# Altium schematic sheet ECG_Regulator.SchDoc(ECG_Regulator)
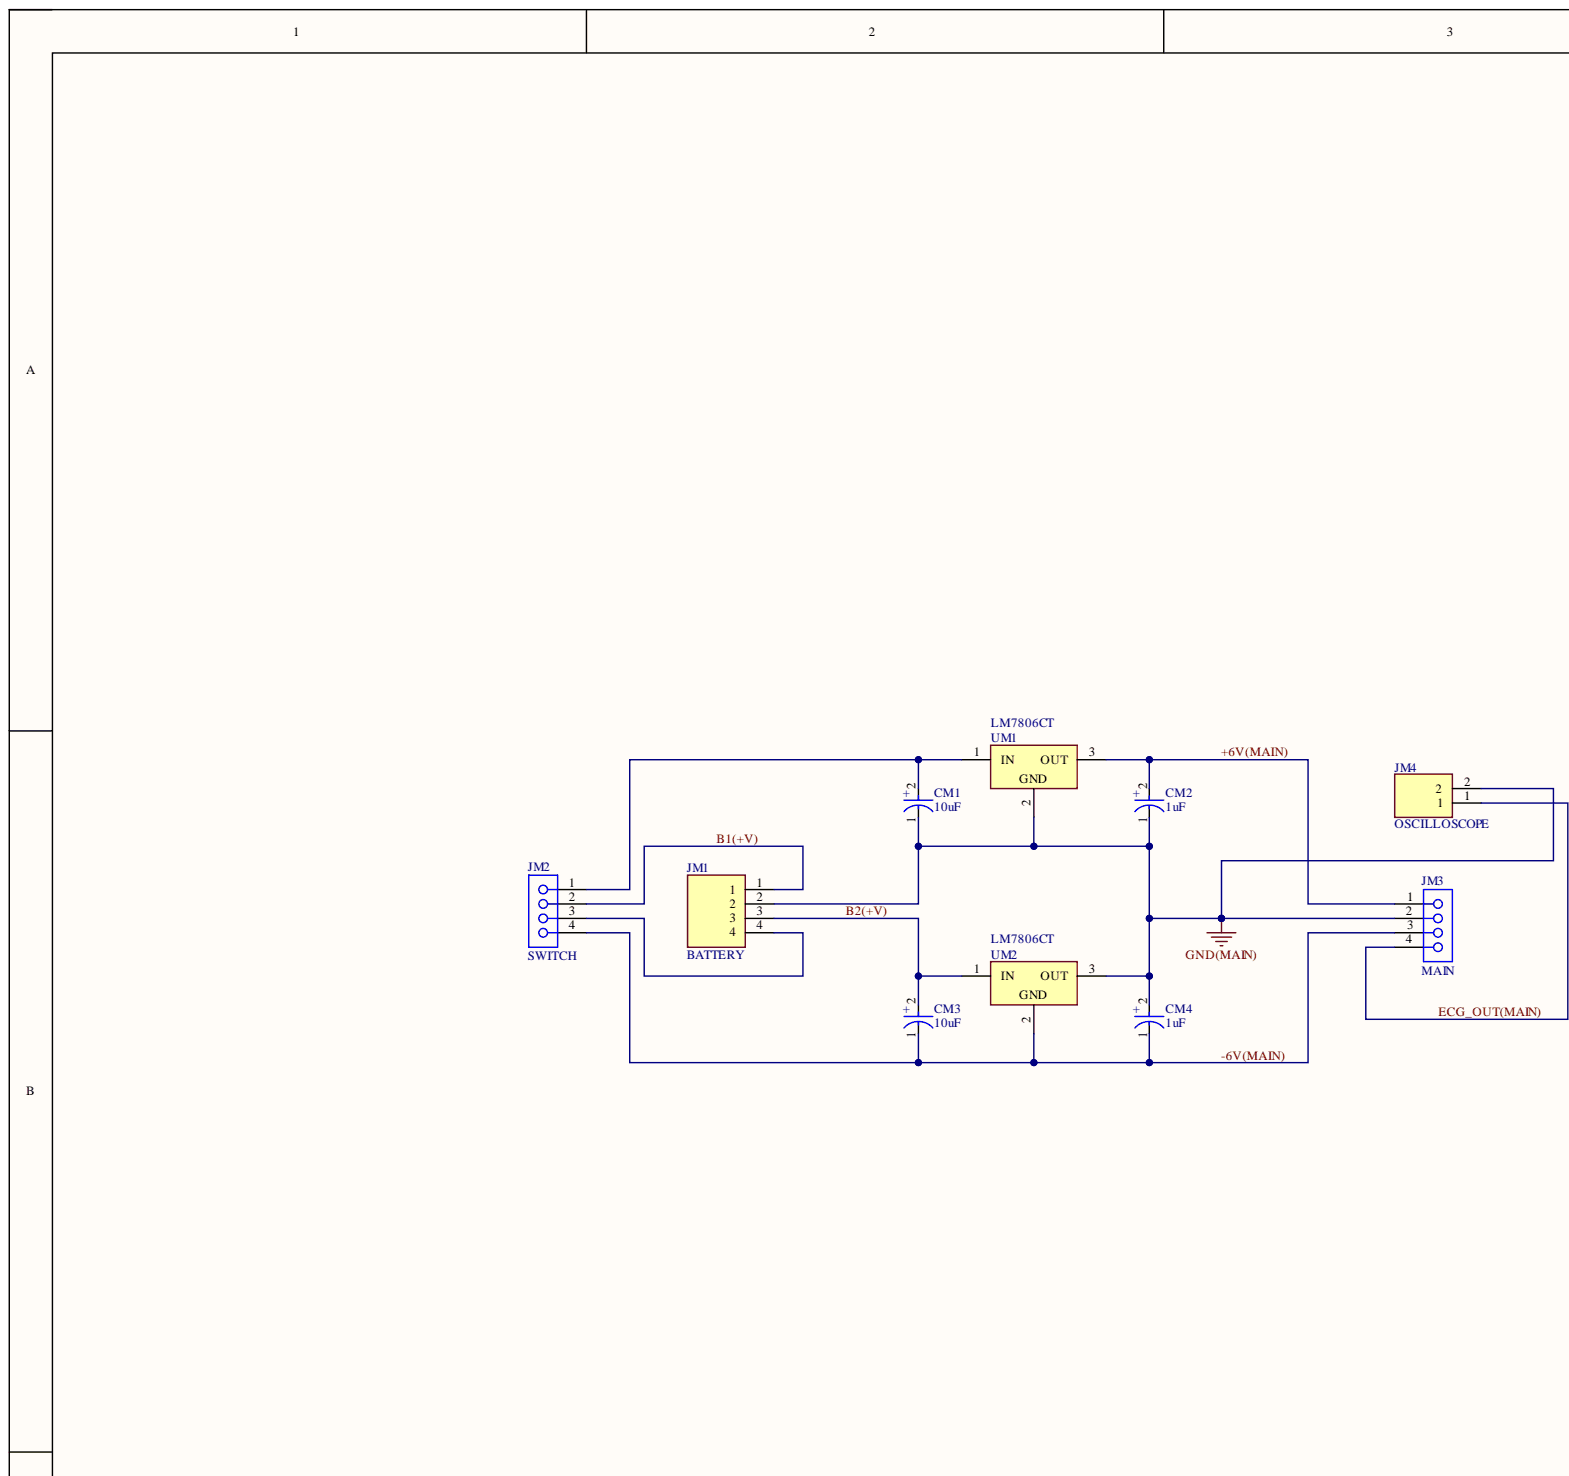
[diagram p0: ECG_Regulator.SchDoc, top left]
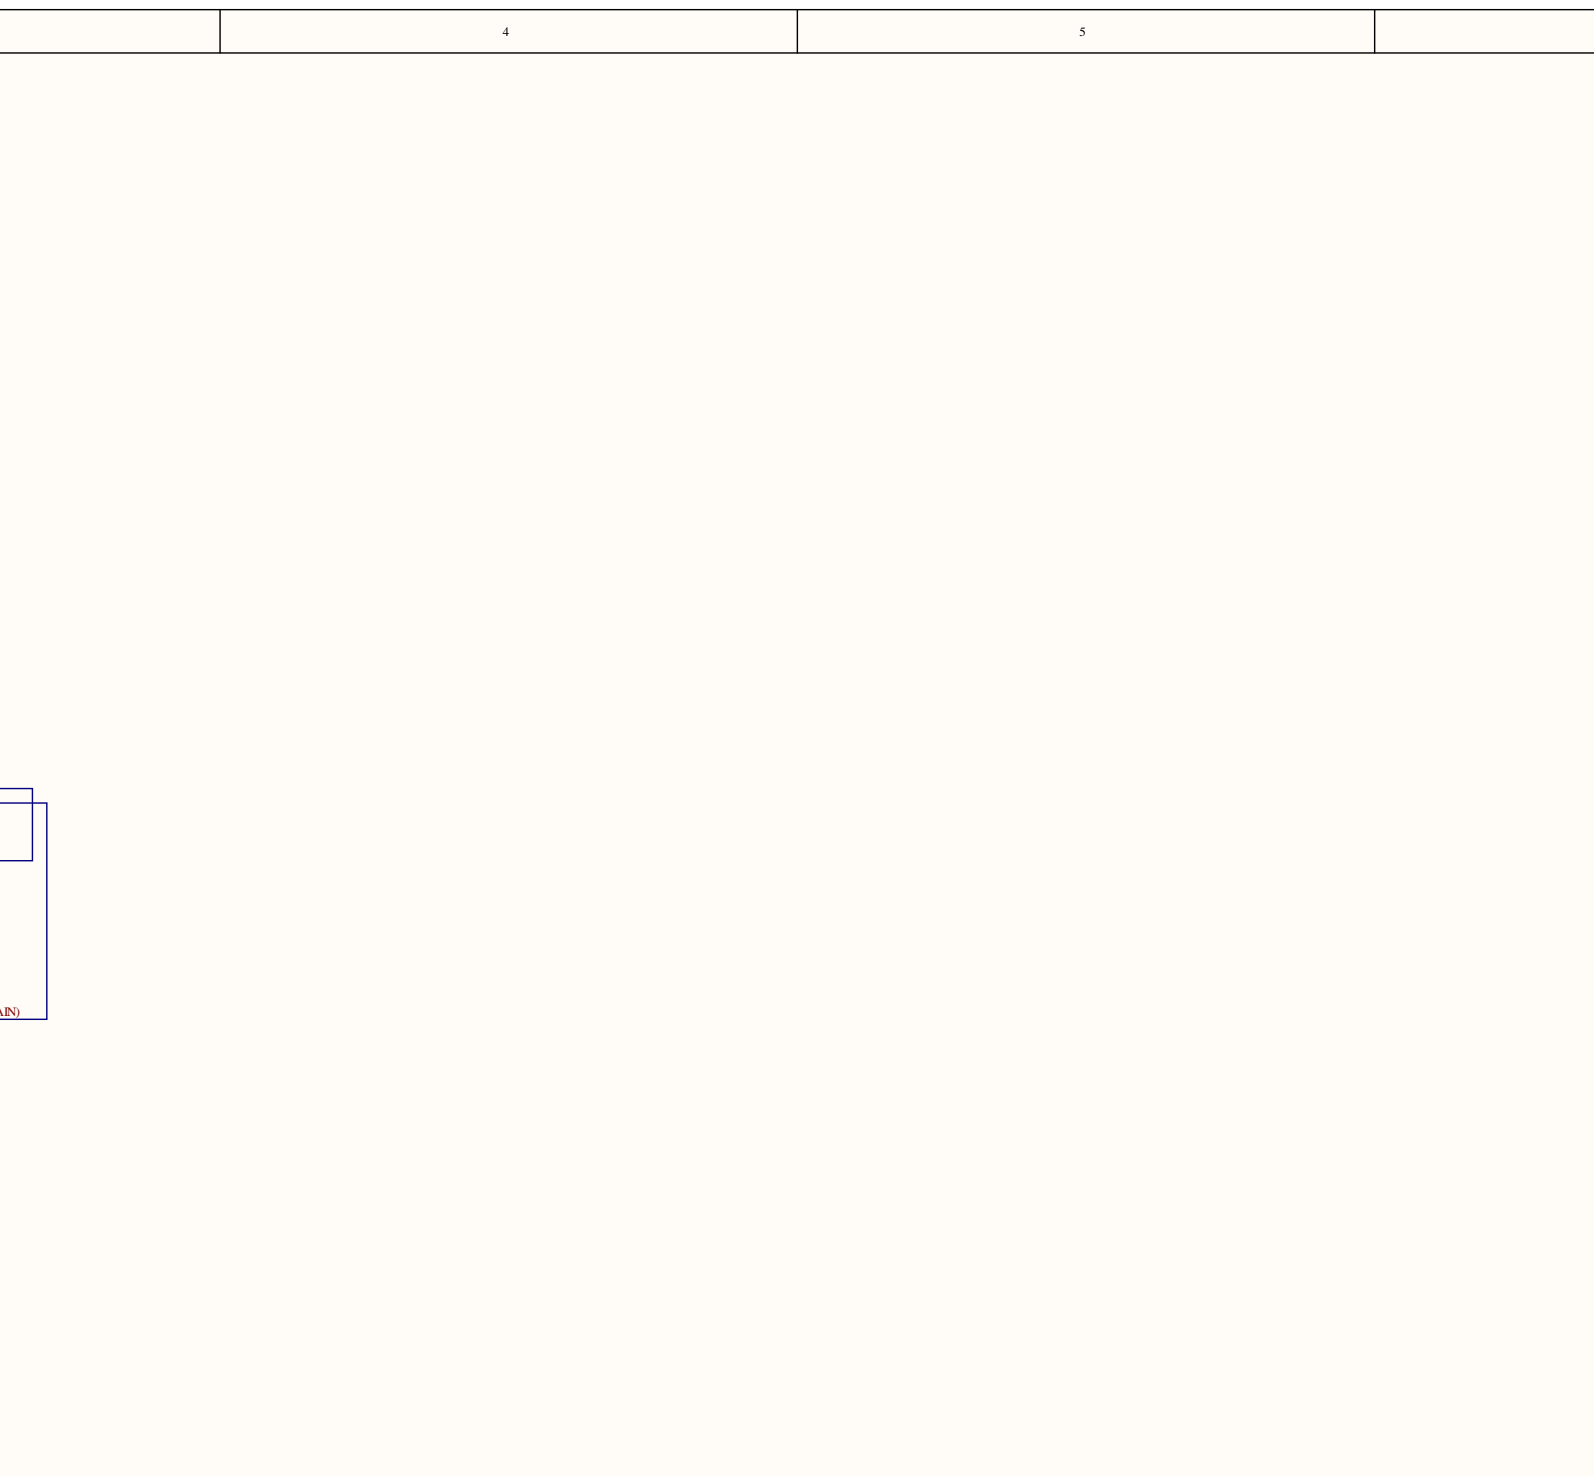
[diagram p1: ECG_Regulator.SchDoc, top centre]
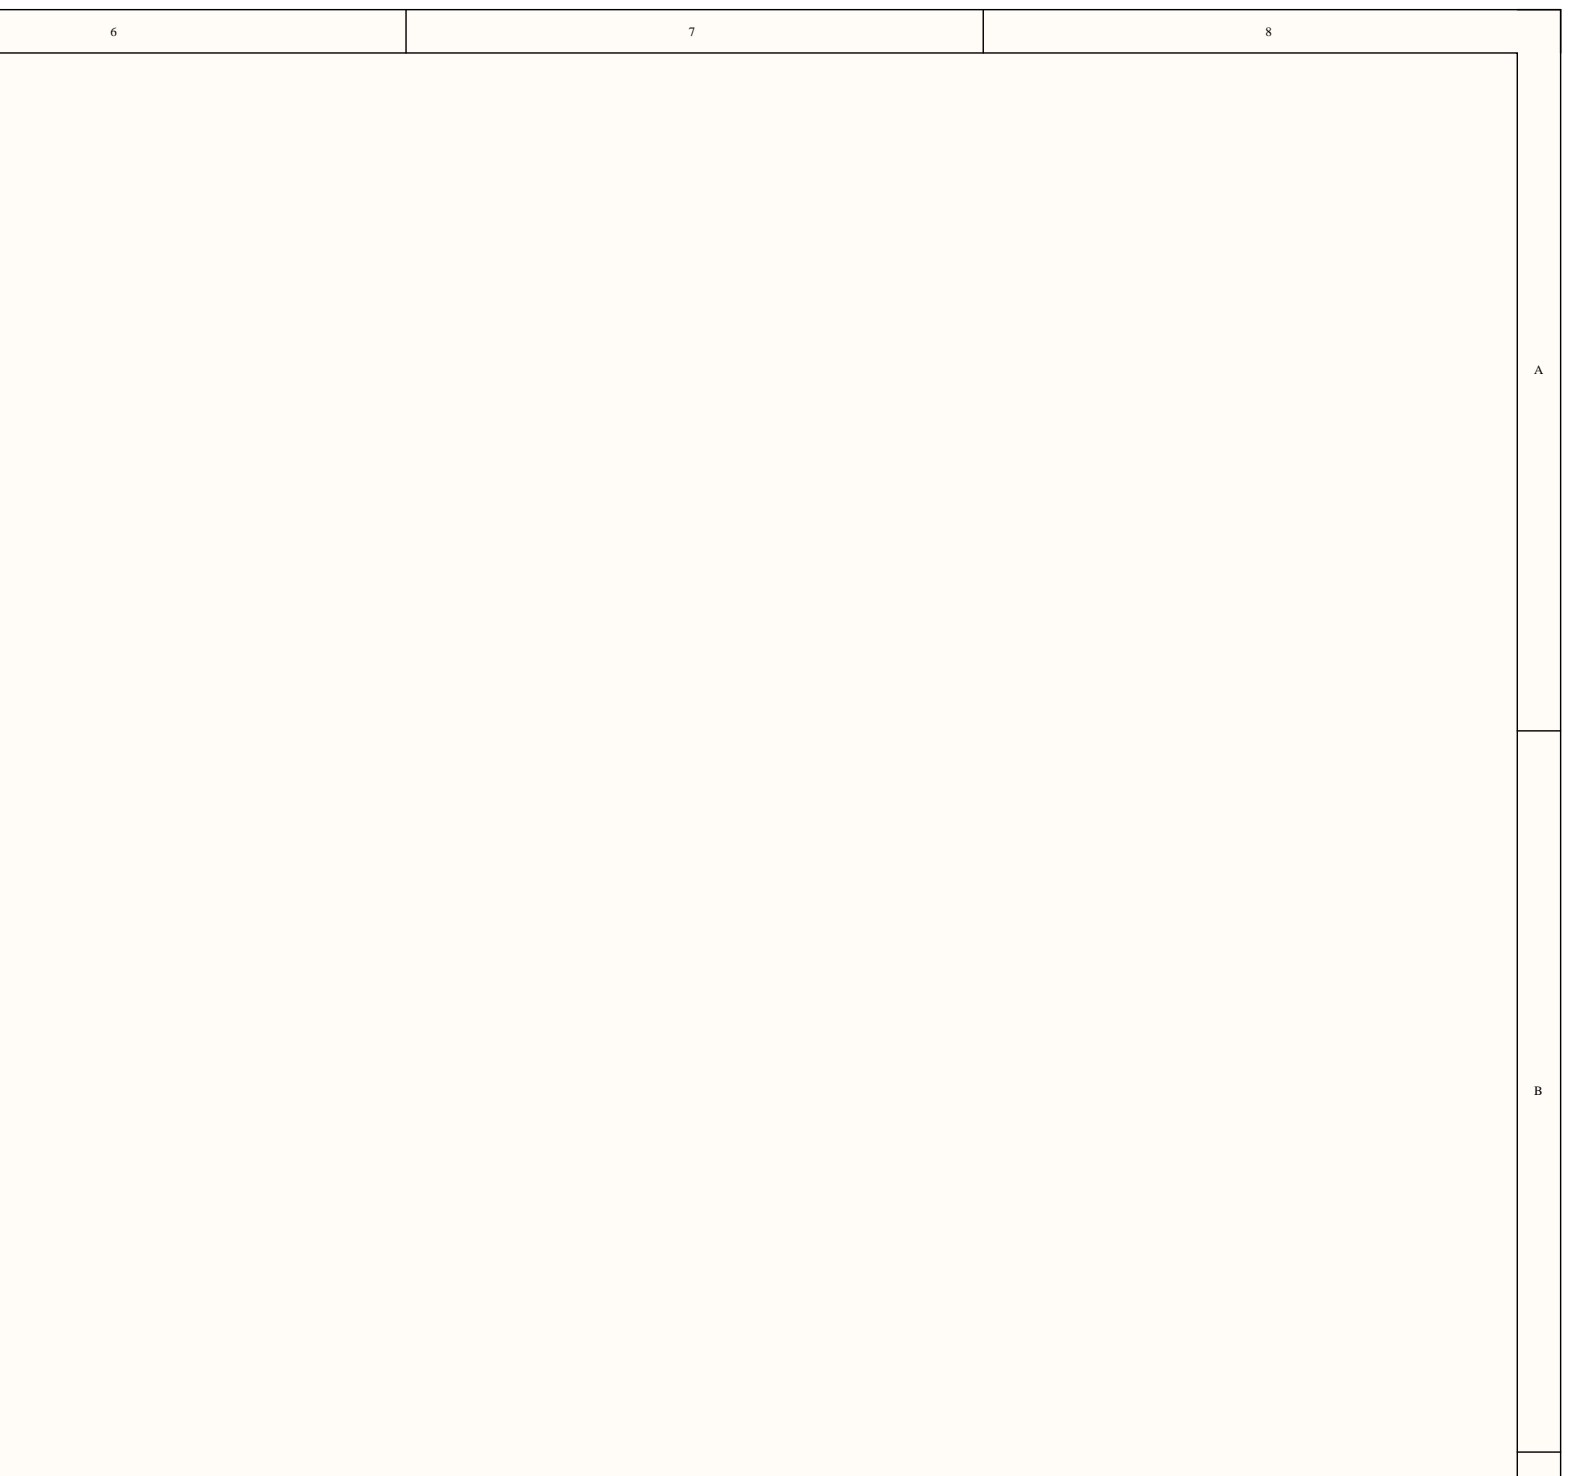
[diagram p2: ECG_Regulator.SchDoc, top right]
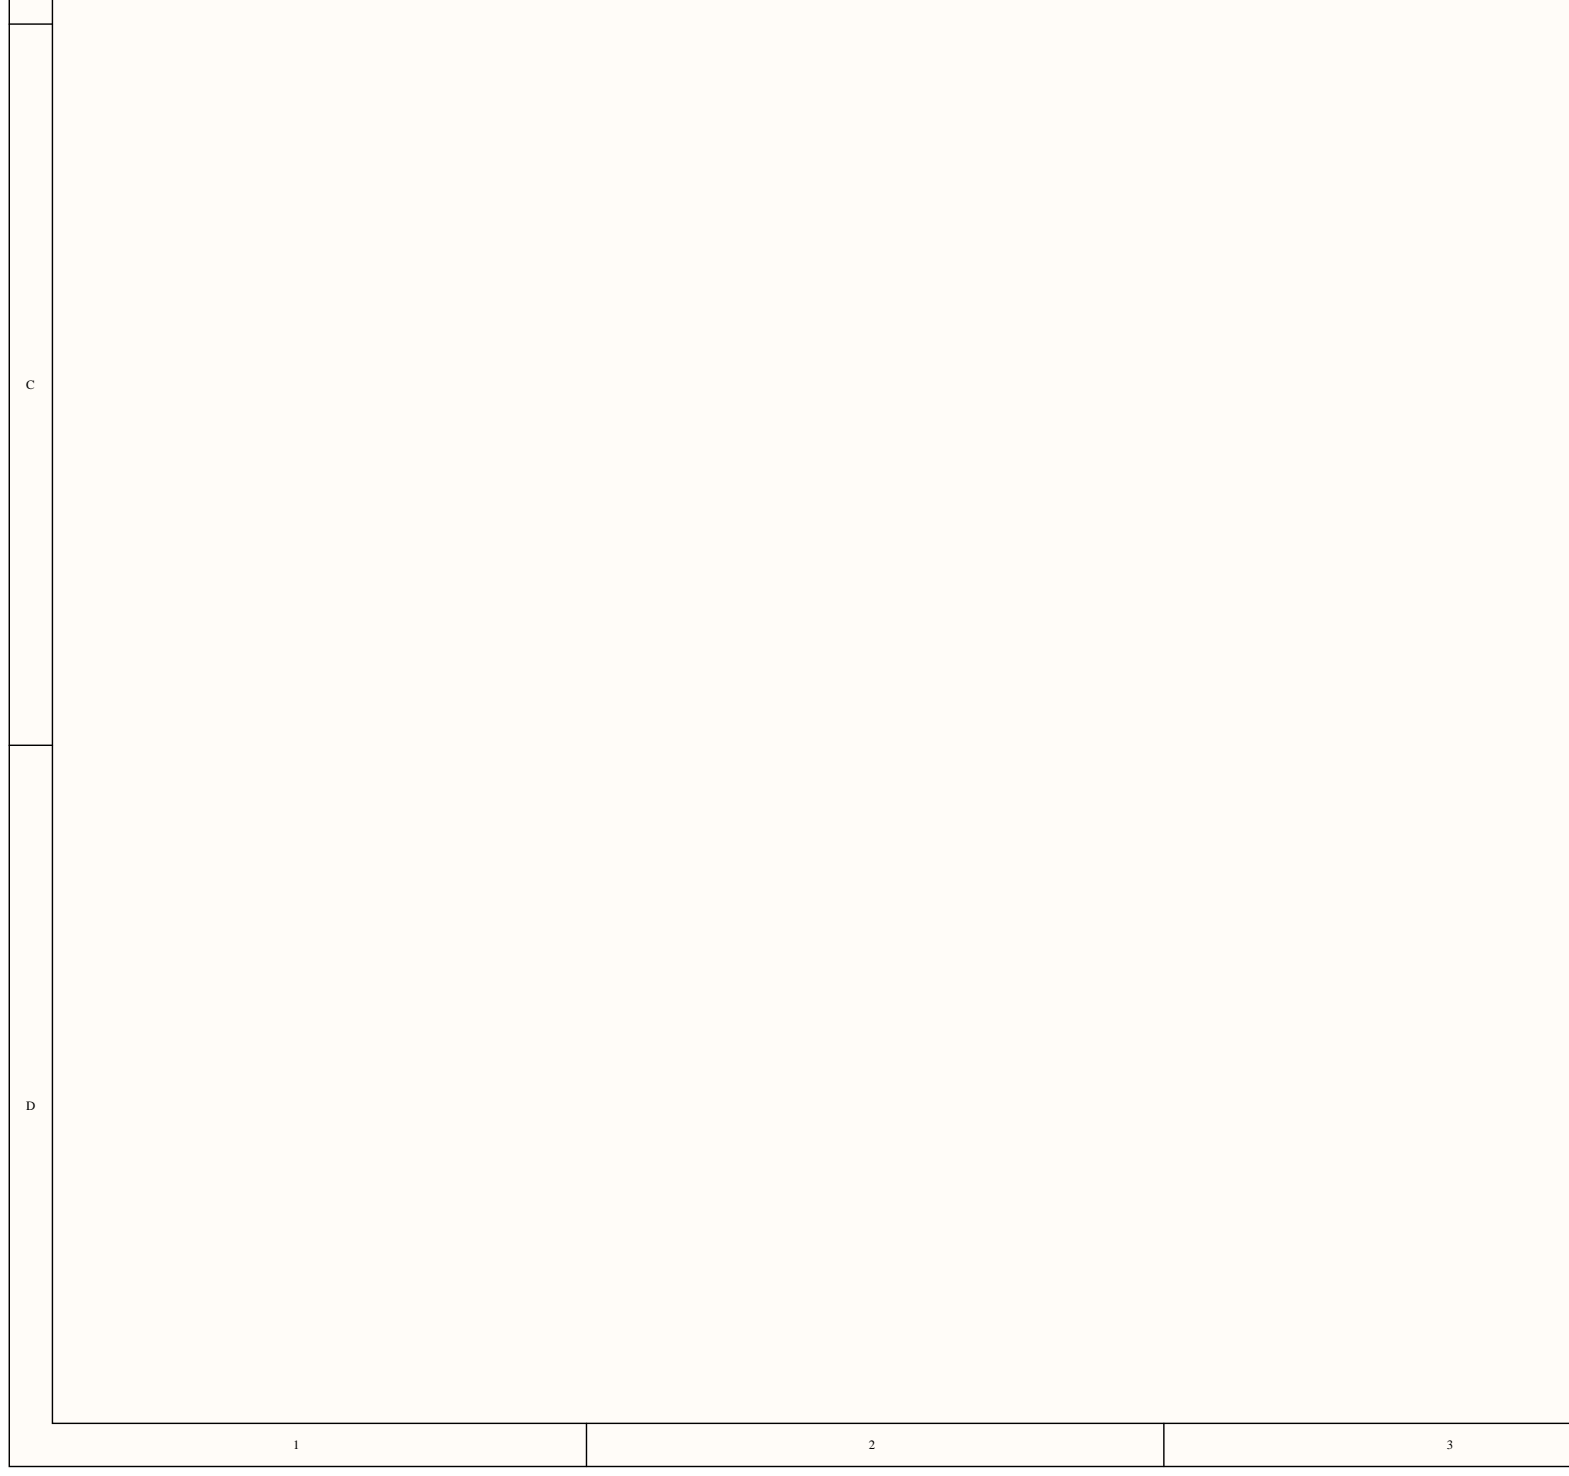
[diagram p3: ECG_Regulator.SchDoc, bottom left]
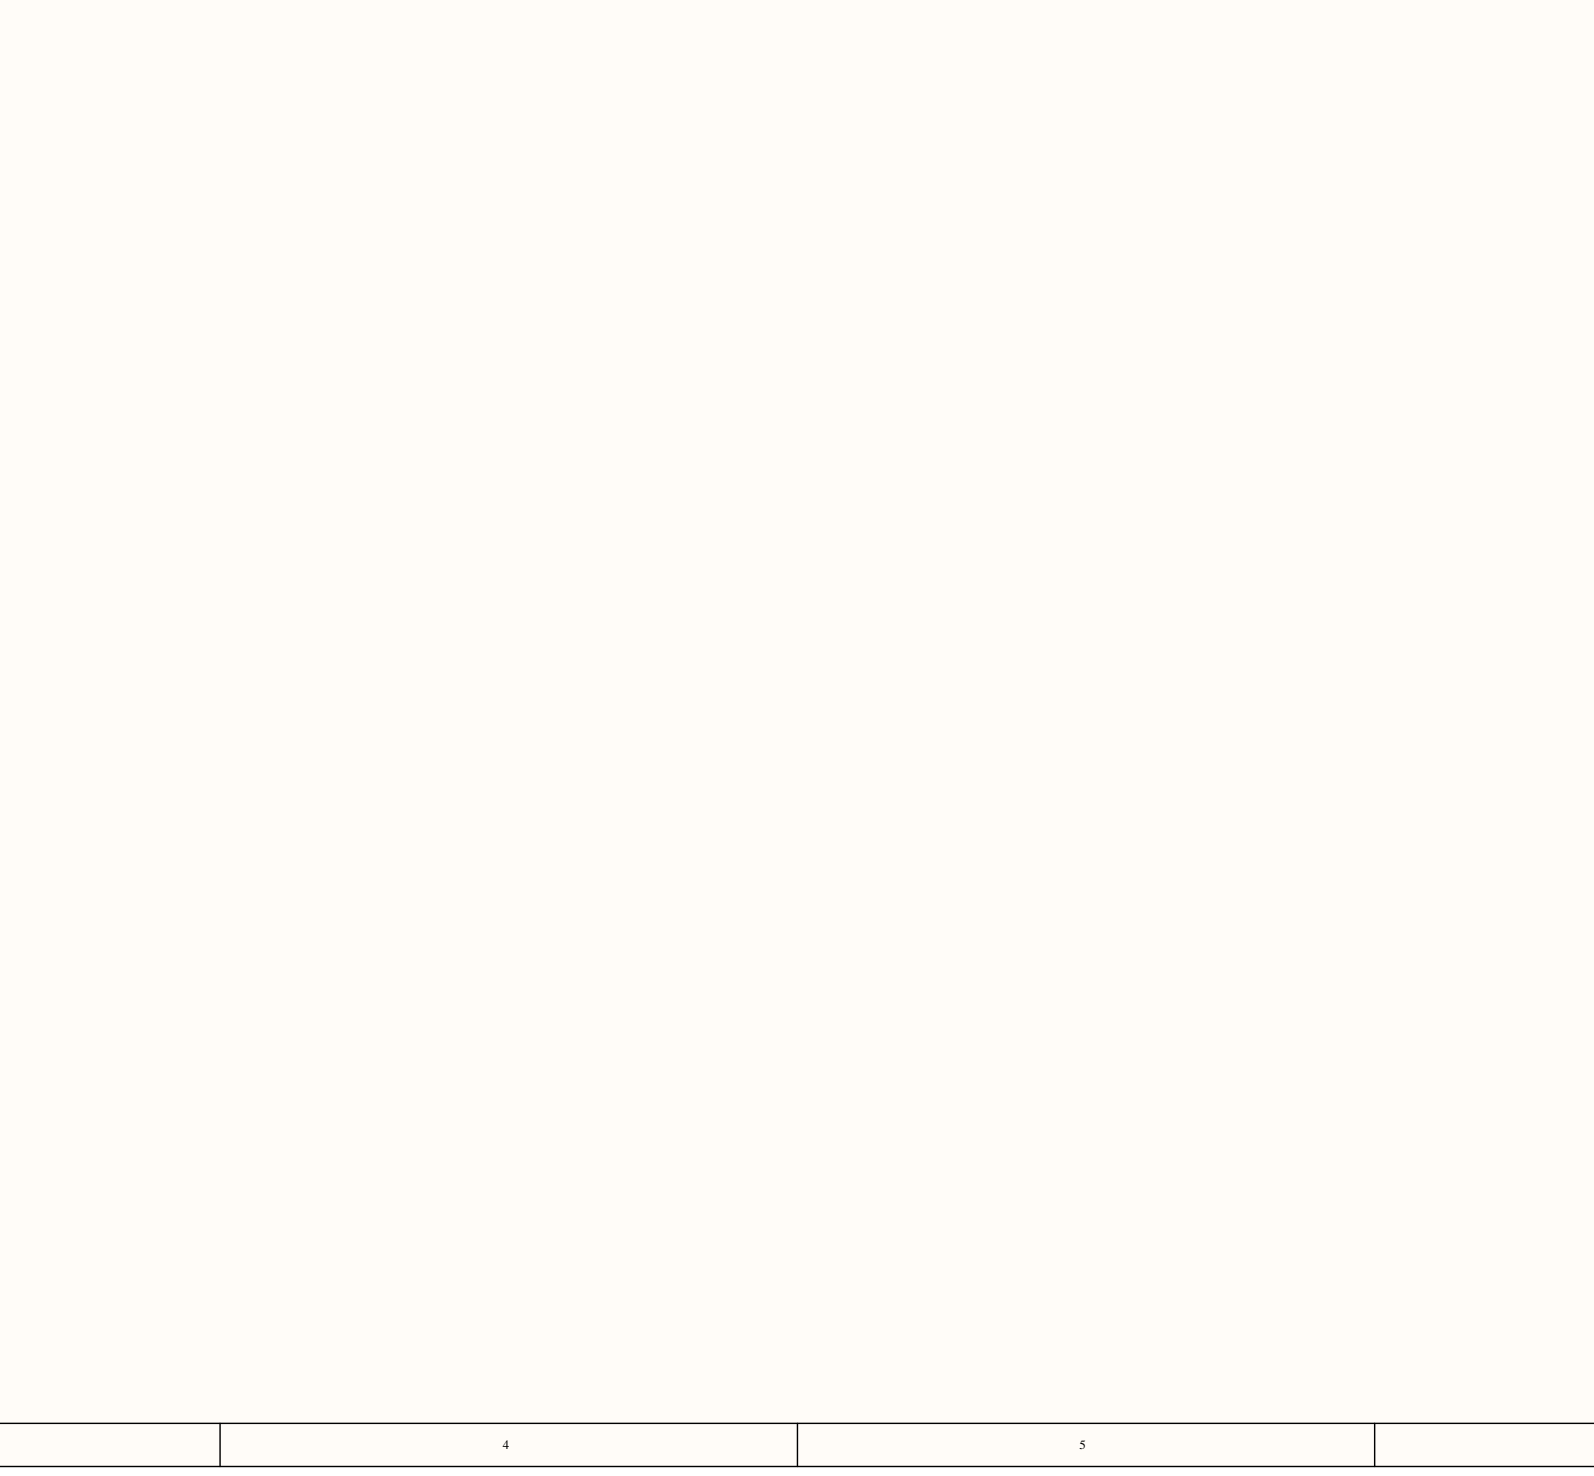
[diagram p4: ECG_Regulator.SchDoc, bottom centre]
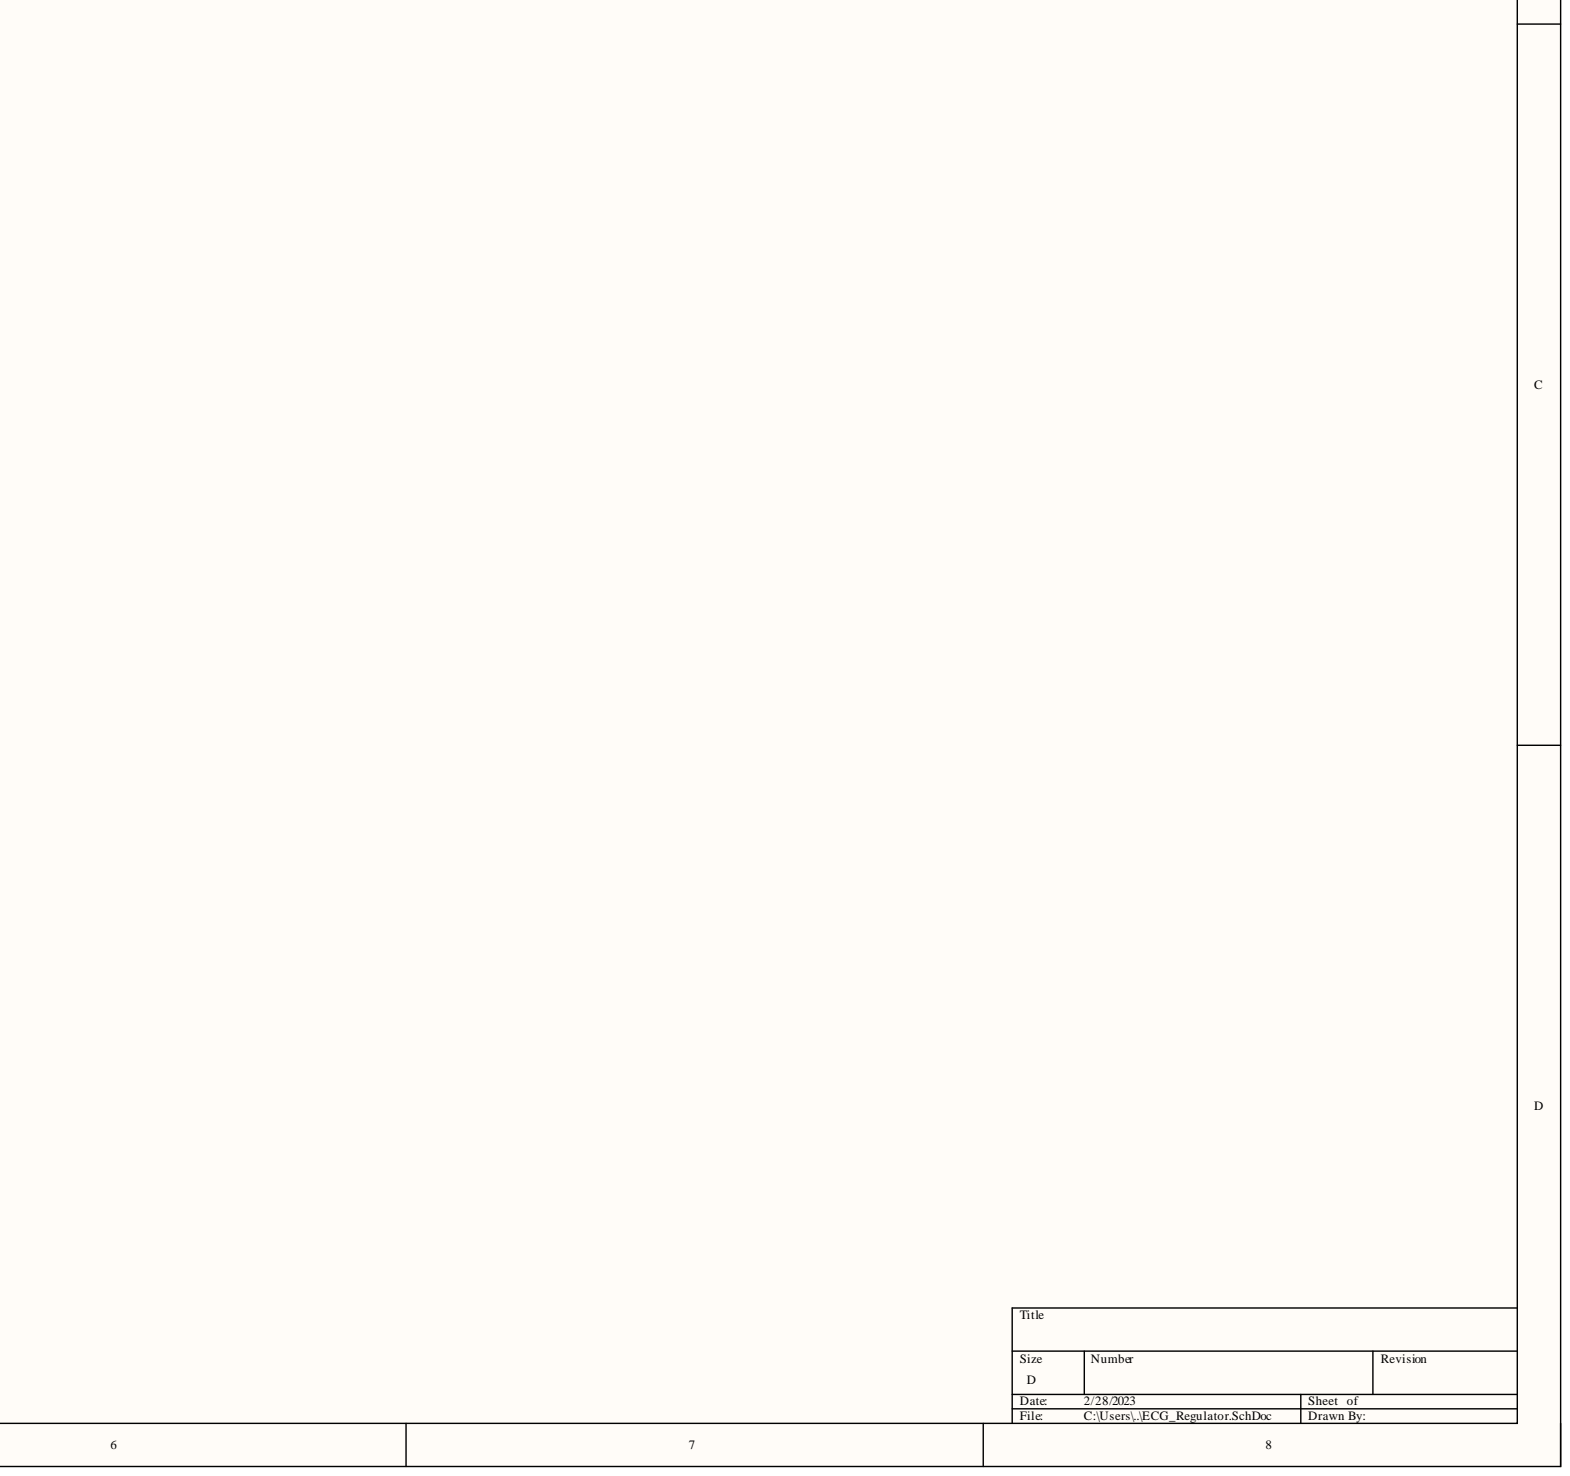
[diagram p5: ECG_Regulator.SchDoc, bottom right]

## Parts
CM1  10uF  [FP-RAD-TH-D_6_3_0_5-L_11-MFG]
CM2  1uF  [FP-RAD-TH-D_6_3_0_5-L_11-MFG]
CM3  10uF  [FP-RAD-TH-D_6_3_0_5-L_11-MFG]
CM4  1uF  [FP-RAD-TH-D_6_3_0_5-L_11-MFG]
JM1  BATTERY  [CUI_TB001-500-04BE]
JM2  SWITCH  [SMTC-SLW-104-01-X-S]
JM3  MAIN  [SMTC-SLW-104-01-X-S]
JM4  OSCILLOSCOPE  [CUI_TB001-500-02BE]
UM1  LM7806CT  [TO220]
UM2  LM7806CT  [TO220]

## Nets
GND(MAIN): CM1.1 CM2.1 CM4.2 JM1.2 JM3.2 JM4.2 UM1.2 UM2.3
+6V(MAIN): CM2.2 JM3.1 UM1.3
-6V(MAIN): CM3.1 CM4.1 JM2.4 JM3.3 UM2.2
B1(+V): JM1.1 JM2.2
B2(+V): CM3.2 JM1.3 UM2.1
ECG_OUT(MAIN): JM3.4 JM4.1
NetCM1_2: CM1.2 JM2.1 UM1.1
NetJM1_4: JM1.4 JM2.3

## Library data of the parts
CM1-CM4 = CMP-001-00083-1: Cap Aluminum Lytic 100uF 25V 20% (6.3 X 11.2mm) Radial 2.5mm 130mA 1000h 105°C Ammo Pack; Component Kind: Standard; Library Name: University of Moratuwa
JM1 = TB001-500-04BE: MF: {'manufacturer': u'CUI Devices'}; Availability: Unavailable; Component Kind: Standard; Library Name: SnapEDA_Library.IntLib; MP: TB001-500-04BE; Package: None; Price: None
JM2 JM3 = CMP-1023-00130-1: Low Profile Socket Strips, Through-hole, Vertical, -55 to 125 degC, 2.54 mm Pitch, 4-Pin, Female, RoHS; Manufacturer: Samtec; PartNumber: SLW-104-01-G-S; Pitch: 2.54 mm; Component Kind: Standard; ComponentLink1Description: Manufacturer URL; ComponentLink1URL: http://www.samtec.com; ComponentLink2Description: Datasheet; ComponentLink2URL: http://www.samtec.com/documents/webfiles/pdf/SLW.PDF; ComponentLink3Description: Package Specification; ComponentLink3URL: http://www.samtec.com/documents/webfiles/cpdf/SLW-1XX-01-X-X-MKT.pdf; DatasheetVersion: F-214; Library Name: Altium Content Vault; Mounting Technology: Through-hole; Orientation: Vertical; PackageDescription: Low Profile Socket Strip; PackageReference: SLW-104-01-X-S; PackageVersion: X, 9/1987; RoHS: True; Temperature Range: -55 to 125 degC
JM4 = TB001-500-02BE: MF: {'manufacturer': u'CUI Devices'}; Availability: Unavailable; Component Kind: Standard; Library Name: SnapEDA_Library.IntLib; MP: TB001-500-02BE; Package: None; Price: None
UM1 UM2 = CMP-0244-00495-1: Positive Voltage Regulator, 6V, 3-Pin TO-220; Component Kind: Standard; ComponentLink1Description: Manufacturer URL; ComponentLink1URL: http://www.st.com; ComponentLink2Description: Datasheet; ComponentLink2URL: http://www.st.com/internet/com/TECHNICAL_RESOURCES/TECHNICAL_LITERATURE/DATASHEET/CD00000444.pdf; ComponentLink3Description: Package Specification; ComponentLink3URL: http://www.st.com/internet/com/TECHNICAL_RESOURCES/TECHNICAL_LITERATURE/PACKAGE_INFORMATION/CD00004649.pdf; DatasheetVersion: 16/11/2011; Library Name: Altium Content Vault; PackageDescription: TO, Thru-Hole, Vertical, Heatsink Mounted; 3 In-Line Leads; Pitch 2.54 mm; PackageReference: TO220; PackageVersion: ver3.0
